# Altium schematic sheet Connectors.SchDoc(Connectors)
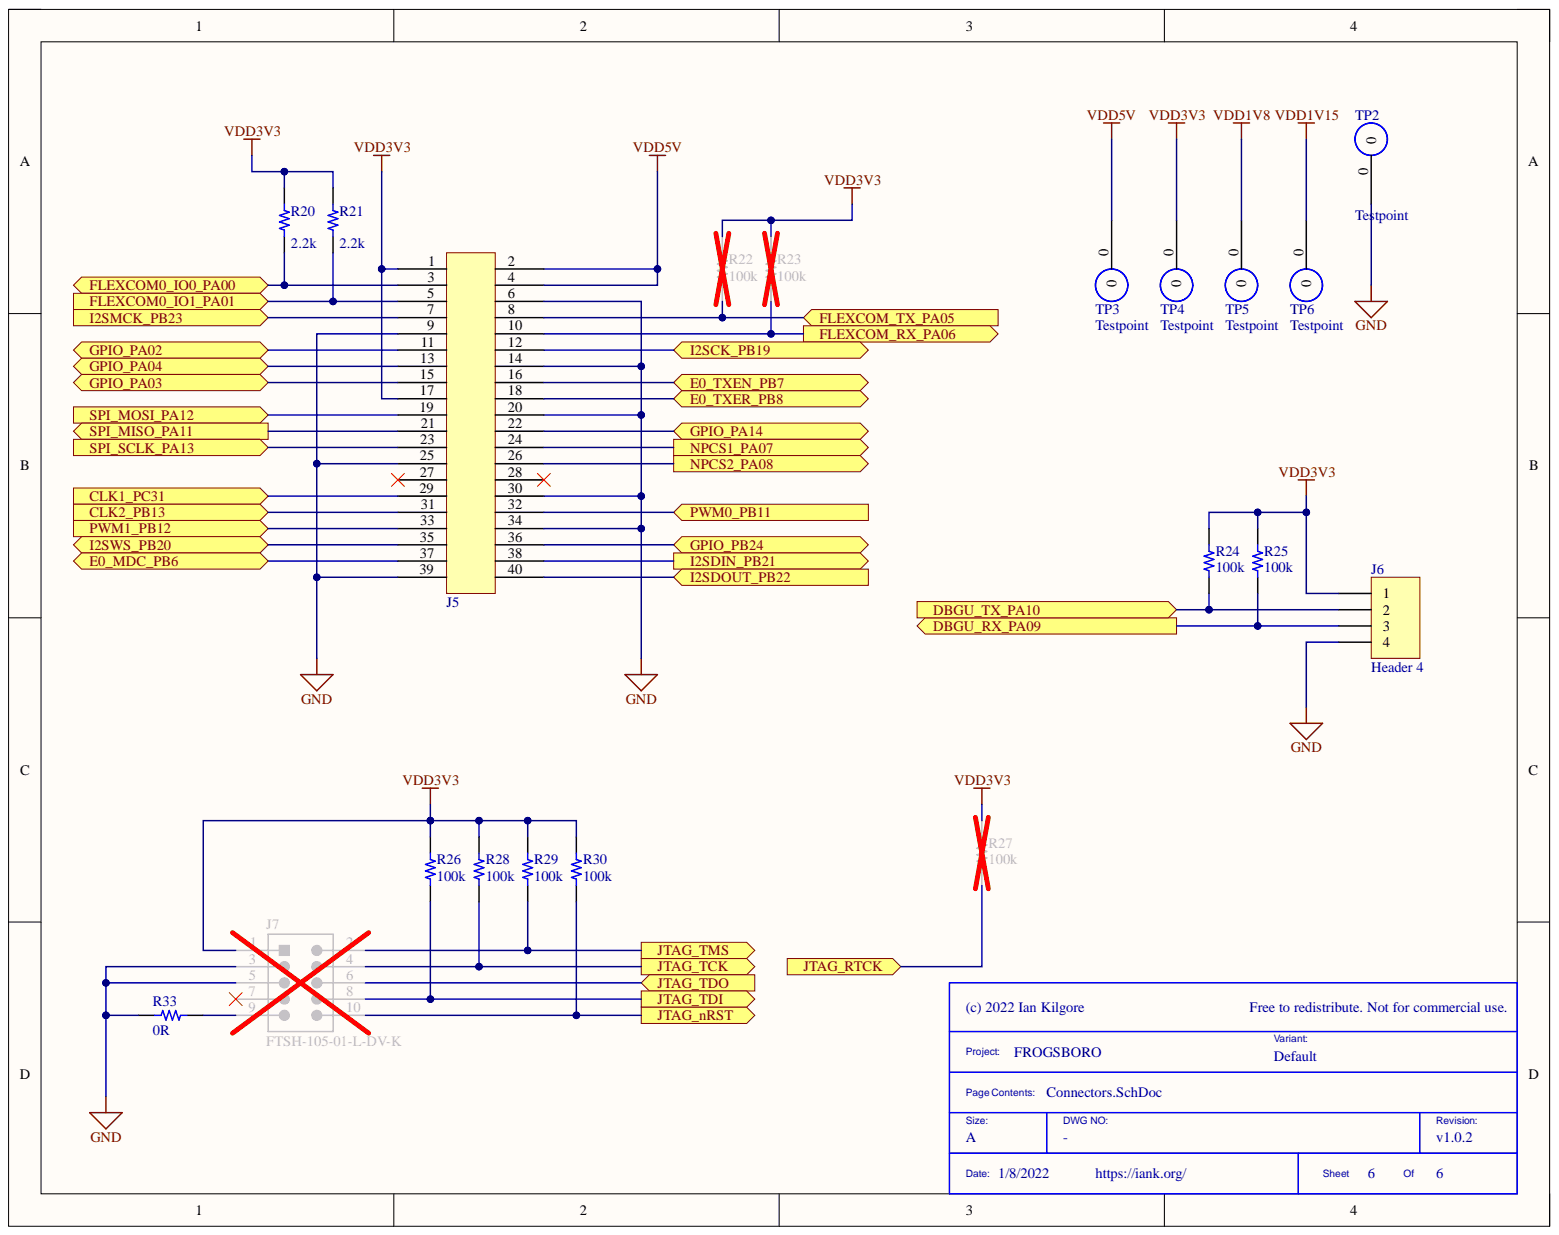
[diagram p0: Connectors.SchDoc]

## Parts
J5  DF23C-40DP-0.5V(92)  [DF23C-40DP-0.5V(92)]  (value not drawn)
J6  Header 4  [HDR1X4]
J7  FTSH-105-01-L-DV-K  [SMTC-FTSH-105-01-L-DV-K_V]
R20  2.2k  [RES 0402_1005]
R21  2.2k  [RES 0402_1005]
R22  100k  [RES 0402_1005]
R23  100k  [RES 0402_1005]
R24  100k  [RES 0402_1005]
R25  100k  [RES 0402_1005]
R26  100k  [RES 0402_1005]
R27  100k  [RES 0402_1005]
R28  100k  [RES 0402_1005]
R29  100k  [RES 0402_1005]
R30  100k  [RES 0402_1005]
R33  0R  [RES 0402_1005]
TP2  Testpoint  [Testpoint]  (no BOM)
TP3  Testpoint  [Testpoint]  (no BOM)
TP4  Testpoint  [Testpoint]  (no BOM)
TP5  Testpoint  [Testpoint]  (no BOM)
TP6  Testpoint  [Testpoint]  (no BOM)

## Ports
CLK1_PC31, CLK2_PB13, DBGU_RX_PA09, DBGU_TX_PA10, E0_MDC_PB6, E0_TXEN_PB7, E0_TXER_PB8, FLEXCOM0_IO0_PA00, FLEXCOM0_IO1_PA01, FLEXCOM_RX_PA06, FLEXCOM_TX_PA05, GPIO_PA02, GPIO_PA03, GPIO_PA04, GPIO_PA14, GPIO_PB24, I2SCK_PB19, I2SDIN_PB21, I2SDOUT_PB22, I2SMCK_PB23, I2SWS_PB20, JTAG_nRST, JTAG_RTCK, JTAG_TCK, JTAG_TDI, JTAG_TDO, JTAG_TMS, NPCS1_PA07, NPCS2_PA08, PWM0_PB11, PWM1_PB12, SPI_MISO_PA11, SPI_MOSI_PA12, SPI_SCLK_PA13

## Nets
GND: J5.6 J5.9 J5.14 J5.20 J5.25 J5.30 J5.34 J5.39 J6.4 J7.3 J7.5 R33.2 TP2.0
VDD1V8: TP5.0
VDD1V15: TP6.0
VDD3V3: J5.1 J5.17 J6.1 J7.1 R20.2 R21.2 R22.2 R23.2 R24.2 R25.2 R26.2 R27.2 R28.2 R29.2 R30.2 TP4.0
VDD5V: J5.2 J5.4 TP3.0
CLK1_PC31: J5.29 ; port CLK1_PC31
CLK2_PB13: J5.31 ; port CLK2_PB13
DBGU_RX_PA09: J6.3 R25.1 ; port DBGU_RX_PA09
DBGU_TX_PA10: J6.2 R24.1 ; port DBGU_TX_PA10
E0_MDC_PB6: J5.37 ; port E0_MDC_PB6
E0_TXEN_PB7: J5.16 ; port E0_TXEN_PB7
E0_TXER_PB8: J5.18 ; port E0_TXER_PB8
FLEXCOM0_IO0_PA00: J5.3 R20.1 ; port FLEXCOM0_IO0_PA00
FLEXCOM0_IO1_PA01: J5.5 R21.1 ; port FLEXCOM0_IO1_PA01
FLEXCOM_RX_PA06: J5.10 R23.1 ; port FLEXCOM_RX_PA06
FLEXCOM_TX_PA05: J5.8 R22.1 ; port FLEXCOM_TX_PA05
GPIO_PA02: J5.11 ; port GPIO_PA02
GPIO_PA03: J5.15 ; port GPIO_PA03
GPIO_PA04: J5.13 ; port GPIO_PA04
GPIO_PA14: J5.22 ; port GPIO_PA14
GPIO_PB24: J5.36 ; port GPIO_PB24
I2SCK_PB19: J5.12 ; port I2SCK_PB19
I2SDIN_PB21: J5.38 ; port I2SDIN_PB21
I2SDOUT_PB22: J5.40 ; port I2SDOUT_PB22
I2SMCK_PB23: J5.7 ; port I2SMCK_PB23
I2SWS_PB20: J5.35 ; port I2SWS_PB20
JTAG_nRST: J7.10 R30.1 ; port JTAG_nRST
JTAG_RTCK: R27.1 ; port JTAG_RTCK
JTAG_TCK: J7.4 R28.1 ; port JTAG_TCK
JTAG_TDI: J7.8 R26.1 ; port JTAG_TDI
JTAG_TDO: J7.6 ; port JTAG_TDO
JTAG_TMS: J7.2 R29.1 ; port JTAG_TMS
NPCS1_PA07: J5.24 ; port NPCS1_PA07
NPCS2_PA08: J5.26 ; port NPCS2_PA08
PWM0_PB11: J5.32 ; port PWM0_PB11
PWM1_PB12: J5.33 ; port PWM1_PB12
SPI_MISO_PA11: J5.21 ; port SPI_MISO_PA11
SPI_MOSI_PA12: J5.19 ; port SPI_MOSI_PA12
SPI_SCLK_PA13: J5.23 ; port SPI_SCLK_PA13
NetJ7_9: J7.9 R33.1

Not connected: J5 27 28; J7 7

## Library data of the parts
J5 = DF23C-40DP-0.5V(92): 0.5mm Pitch Low-Profile (H=1.5mm) Board to Board / FPC to Board Connectors; Manufacturer: Hirose; Connector Type: Plug,PCB; Current Rating: 300mA; Gender: Male; Insulation Resistance: 500MR; Mated Stacking Heights: 1.5mm; Number of Contacts: 40; Row Spacing: 4.3mm; Voltage Rating: 50V; Category: Headers and Wire Housings; Component Kind: Standard; ComponentLink1Description: https://datasheet.ciiva.com/11751/0900766b80f5fb65-11751775.pdf?src-supplier=RSComponents; ComponentLink1URL: https://datasheet.ciiva.com/11751/0900766b80f5fb65-11751775.pdf?src-supplier=RSComponents; ComponentLink2Description: https://datasheet.ciiva.com/397/e68801060-397924.pdf?src-supplier=Digi-Key; ComponentLink2URL: https://datasheet.ciiva.com/397/e68801060-397924.pdf?src-supplier=Digi-Key; ComponentLink3Description: https://datasheet.ciiva.com/13568/e68801060-16897-13568044.pdf?src-supplier=Mouser; ComponentLink3URL: https://datasheet.ciiva.com/13568/e68801060-16897-13568044.pdf?src-supplier=Mouser; ComponentLink4Description: https://datasheet.ciiva.com/8038/e68801060-8038886.pdf?src-supplier=Element14; ComponentLink4URL: https://datasheet.ciiva.com/8038/e68801060-8038886.pdf?src-supplier=Element14; Contact Gender: Male; Contact Material: Bronze,Copper Alloy; Contact Plating: Gold; Flammability Rating: UL94 V-0; Housing Color: Black; Housing Material: Polymer; Lead Free: Lead Free; Manufacturer Part Number: DF23C-40DP-0.5V(92); Max Operating Temperature: 85°C; Min Operating Temperature: -35°C; Mount: Surface Mount; Number of Positions: 40; Number of Rows: 2; Orientation: Straight; Package Quantity: 2000; Packaging: Tape and Reel; Pitch: 0.5mm; Pricing 1: 1=1.25000, 10=1.09700, 25=1.03200, 50=0.98900, 100=0.94600, 250=0.86000, 500=0.77400, 1000=0.68800 (USD); REACH SVHC: No SVHC; RoHS: Yes; RoHS Compliant: Yes; Series: DF23; Stock 1: 419; Supplier 1: Digi-Key; Supplier Part Number 1: H124750CT-ND; Termination: SMD/SMT; Library Name: FROGSBORO.SchLib
J6 = Header 4: Header, 4-Pin; Component Kind: Standard; LatestRevisionDate: 17-Jul-2002; LatestRevisionNote: Re-released for DXP Platform.; Library Name: Miscellaneous Connectors.IntLib; Signal Integrity: Connector; Publisher: Altium Limited
J7 = CMP-2000-05353-1: Male Header, Pitch 1.27 mm, 2 x 5 Position, Height 6.25 mm; Manufacturer: Samtec; Connector Type: Header,Unshrouded; Mating Products: SFMC-105-L2-S-D-TR-ND  -CONN.05"SURFACE10POSSMT  FLE-105-01-G-DV-TR-ND  -CONNSKT1.27MM10POSDUALSMD  FLE-105-01-G-DV-A-P-ND  -CONNSKT1.27MM10POSDUALSMD  FLE-105-01-G-DV-A-ND  -CONNSKT1.27MM10POSDUALSMD  CLP-105-02-G-D-P-TR-ND  -CONNRCPT10POSDUAL.05"SMD  SAM1155-05-ND  -CONNRCPT10POSDUAL.05"SMD  SAM1154-05-ND  -CONNRCPT10POSDUAL.05"SMD  SAM1153-05-ND  -CONNRCPT10POSDUAL.05"SMD  SAM1226-05-ND  -CONNRCPT.05"10POSDUALSMD; Number of Positions: 10; Number of Positions Loaded: All; Other Names: Q3937619; Pitch: 0.050"(1.27mm); Product Training Modules: Board-to-BoardConnectors; Category: Connectors,Interconnects; Color: Black; Component Kind: Standard; Contact Finish: Gold; Contact Finish Thickness: 10µin(0.25µm); Contact Mating Length: 0.120"(3.05mm); Contact Type: MalePin; Datasheet URL: http://suddendocs.samtec.com/prints/ftsh-1xx-xx-xxx-dv-xxx-mkt.pdf; Datasheet Version: Rev. ET, 10/1995; Family: RectangularConnectors-Headers,MalePins; Library Name: Altium Content Vault; Manufacturer URL: http://www.samtec.com/; Mounting Type: SurfaceMount; Number of Rows: 2; Package Description: Male Header, Pitch 1.27 mm, 2 x 5 Position, Height 5.97 mm, Tail Length 4.32 mm; Package Reference: FTSH-105-01-L-DV-K; Package URL: http://suddendocs.samtec.com/prints/ftsh-1xx-xx-xxx-dv-xxx-footprint.pdf; Packaging: Tube; Row Spacing: 0.050"(1.27mm); Series: FTSH; Standard Package: 1; Termination: Solder
R20 R21 = RCS04022K20FKED: RES SMD 2.2K OHM 1% 1/5W 0402; Database Table Name: Resistors - Surface Mount - 0402; Component Kind: Standard; Library Name: Celestial Altium Library - altium_library.DbLib; Part Number: RCS04022K20FKED; Value: 2.2k
R22-R30 = CRCW0402100KFKEDC: RES SMD 100K OHM 1% 1/16W 0402; Manufacturer: Vishay Dale; Database Table Name: Resistors - Surface Mount - 0402; Power (Watts): 0.063W, 1/16W; Resistance: 100 kOhms; Temperature Coefficient: ±100ppm/°C; Component Kind: Standard; ComponentLink1Description: Datasheet; ComponentLink1URL: http://www.vishay.com/docs/28773/crcwce3.pdf; ComponentLink2Description: DigiKey Link; ComponentLink2URL: http://digikey.com/product-detail/en/vishay-dale/CRCW0402100KFKEDC/541-3960-1-ND/7928643; Composition: Thick Film; Device Package: 0402 (1005 Metric); Height - Seated (Max): 0.014" (0.35mm); LastUpdated: 9/2/2020 1:07:49 AM; Library Name: Celestial Altium Library - altium_library.DbLib; Lifecycle Status: Active; Minimum Order: 1; Number of Terminations: 2; Operating Temperature: -55°C ~ 155°C; Package / Case: 0402 (1005 Metric); Packaging: CutTape; Part Number: CRCW0402100KFKEDC; Part Status: Active; PartId: 12665; Price: 0.10; Series: CRCW-C; Size / Dimension: 0.039" L x 0.020" W (1.00mm x 0.50mm); Supplier 1: DigiKey; Supplier Device Package: 0402; Supplier Part Number 1: 541-3960-1-ND; Tolerance: ±1%; Value: 100k
R33 = CRG0402ZR: RES SMD 0 OHM JUMPER 1/16W 0402; Manufacturer: TE Connectivity Passive Product; Database Table Name: Resistors - Surface Mount - 0402; Power (Watts): 0.063W, 1/16W; Component Kind: Standard; ComponentLink1Description: Datasheet; ComponentLink1URL: https://www.te.com/commerce/DocumentDelivery/DDEController?Action=srchrtrv&DocNm=1773204&DocType=DS&DocLang=English; ComponentLink2Description: DigiKey Link; ComponentLink2URL: http://digikey.com/product-detail/en/te-connectivity-passive-product/CRG0402ZR/A106025CT-ND/3477649; Composition: Thick Film; Device Package: 0402 (1005 Metric); Height - Seated (Max): 0.016" (0.40mm); LastUpdated: 9/2/2020 1:07:28 AM; Library Name: Celestial Altium Library - altium_library.DbLib; Lifecycle Status: Active; Minimum Order: 1; Number of Terminations: 2; Operating Temperature: -55°C ~ 155°C; Package / Case: 0402 (1005 Metric); Packaging: CutTape; Part Number: CRG0402ZR; Part Status: Active; PartId: 20614; Price: 0.10; Series: CRG, Neohm; Size / Dimension: 0.039" L x 0.020" W (1.00mm x 0.50mm); Supplier 1: DigiKey; Supplier Device Package: 0402; Supplier Part Number 1: A106025CT-ND; Tolerance: Jumper; Value: 0R
TP2-TP6 = Testpoint: Library Name: FROGSBORO.SchLib
